# Altium schematic sheet Power.SchDoc(Power)
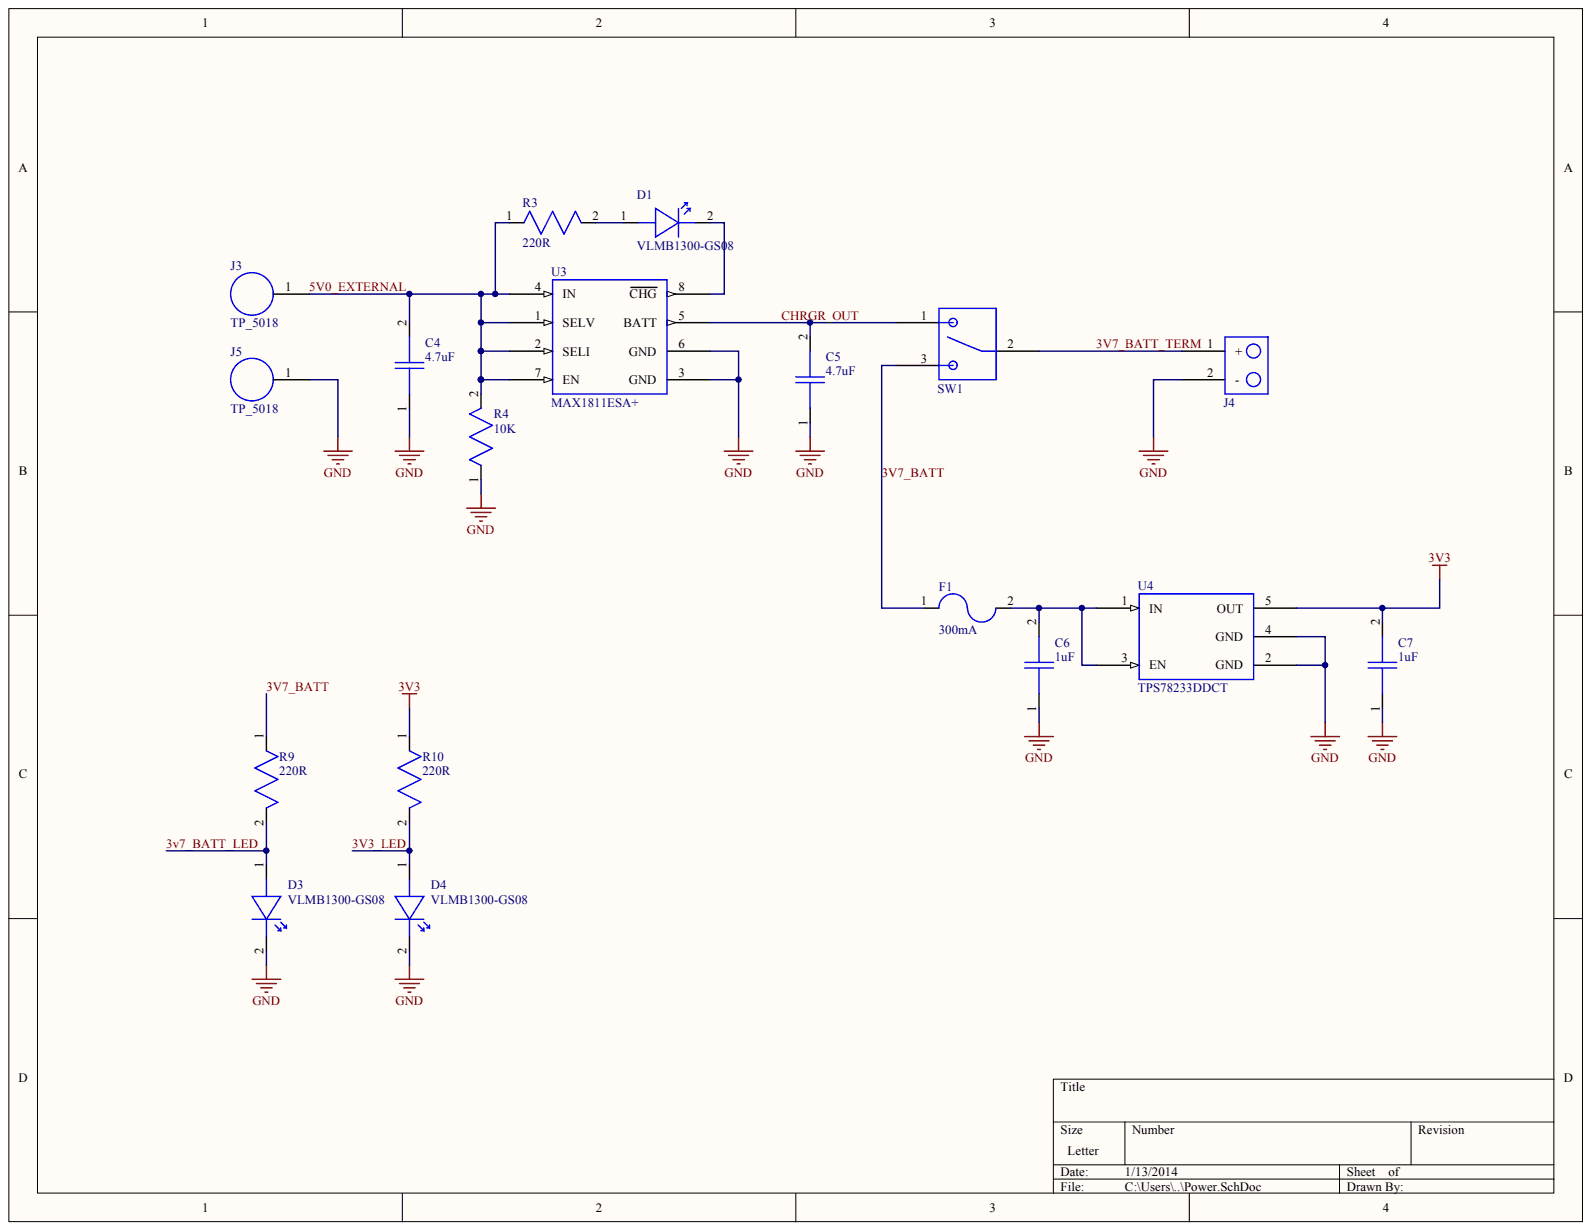
[diagram p0: Power.SchDoc]

## Parts
C4  Inductance: 4.7uF  (hidden comment: CAP_0603_4u7)
C5  Inductance: 4.7uF  (hidden comment: CAP_0603_4u7)
C6  Inductance: 1uF  (hidden comment: CAP_0603_1u)
C7  Inductance: 1uF  (hidden comment: CAP_0603_1u)
D1  Part Number: VLMB1300-GS08  (hidden comment: LED_BLUE)
D3  Part Number: VLMB1300-GS08  (hidden comment: LED_BLUE)
D4  Part Number: VLMB1300-GS08  (hidden comment: LED_BLUE)
F1  Itrip: 300mA  (hidden comment: POLYFUSE_300mA_1210)
J3  TP_5018
J4  CONN_BATT_2POS_MOLEX  (value not drawn)
J5  TP_5018
R3  Resistance: 220R  (hidden comment: RES_0603_220R)
R4  Resistance: 10K  (hidden comment: RES_0603_10K)
R9  Resistance: 220R  (hidden comment: RES_0603_220R)
R10  Resistance: 220R  (hidden comment: RES_0603_220R)
SW1  SW_SPDT_SMD  (value not drawn)
U3  MAX1811  Part Number: MAX1811ESA+
U4  TPS78233  Part Number: TPS78233DDCT

## Nets
3V3: C7.2 R10.1 U4.5(OUT)
GND: C4.1 C5.1 C6.1 C7.1 D3.2 D4.2 J4.2 J5.1 R4.1 U3.3(GND) U3.6(GND) U4.2(GND) U4.4(GND)
3V3_LED: D4.1 R10.2
3V7_BATT: F1.1 R9.1 SW1.3
3V7_BATT_LED: D3.1 R9.2
3V7_BATT_TERM: J4.1 SW1.2
5V0_EXTERNAL: C4.2 J3.1 R3.1 R4.2 U3.1(SELV) U3.2(SELI) U3.4(IN) U3.7(EN)
CHRGR_OUT: C5.2 SW1.1 U3.5(BATT)
NetC6_2: C6.2 F1.2 U4.1(IN) U4.3(EN)
NetD1_1: D1.1 R3.2
NetD1_2: D1.2 U3.8(CHG)

## Library data of the parts
C4 C5 = (no symbol name): Manufacturer: Kemet; Part Number: C0603C475K9PACTU
C6 C7 = (no symbol name): Manufacturer: AVX; Part Number: 0603ZD105KAT2A
D1 D3 D4 = (no symbol name): Manufacturer: Vishay; Colour: Blue
F1 = (no symbol name): Manufacturer: Bourns; Part Number: MF-USMF010-2; Ihold: 100mA; Package: 1210
J3 J5 = (no symbol name): Manufacturer: Keystone Electronics; Part Number: 5018
J4 = (no symbol name): Manufacturer: Molex; Part Number: 22-05-7025
R3 R9 R10 = (no symbol name): Manufacturer: KOA Speer; Part Number: RK73H1JTTD2200F
R4 = (no symbol name): Manufacturer: KOA Speer; Part Number: RK73H1JTTD1002F
SW1 = (no symbol name): Manufacturer: ALPS; Part Number: SSSS810701
U3 = (no symbol name): Manufacturer: Maxim; Package: SOIC-8
U4 = (no symbol name): Manufacturer: Texas Instruments; Package: TSOT23-5
